# Altium schematic sheet W800.SchDoc
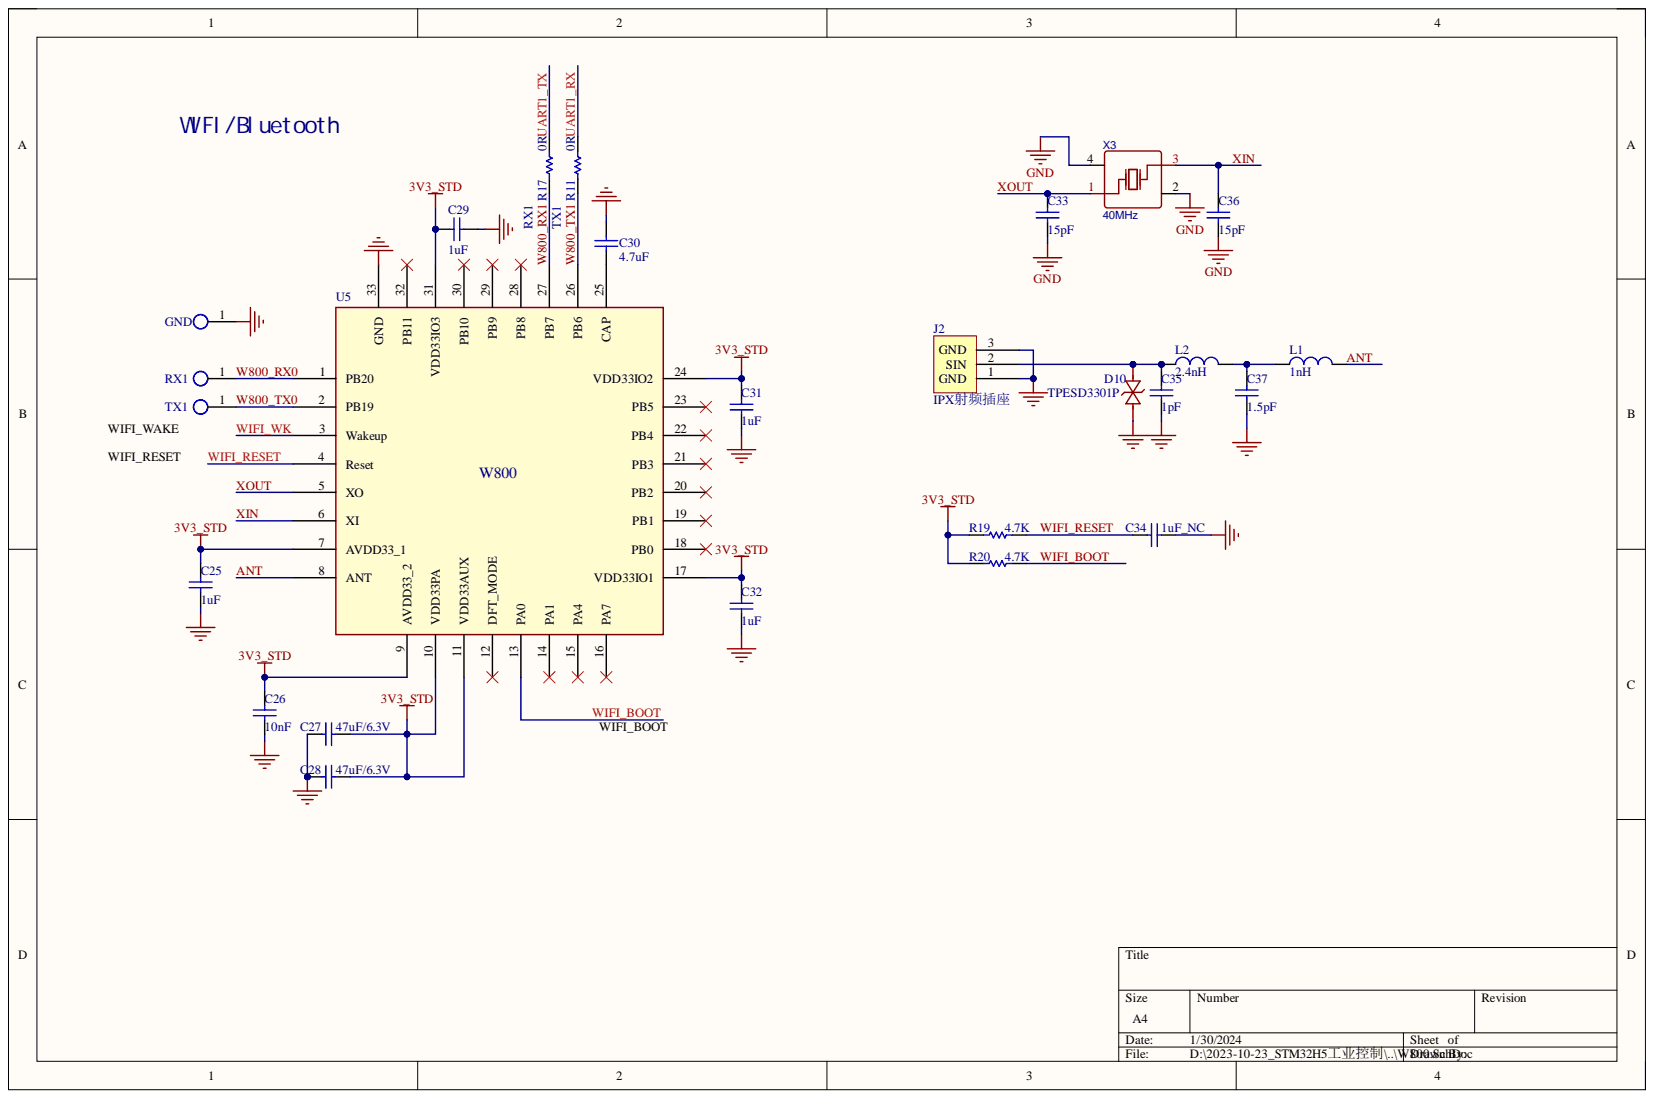
[diagram p0: W800.SchDoc]

## Parts
C25  1uF  [0201]
C26  10nF  [0201]
C27  47uF/6.3V  [0603]
C28  47uF/6.3V  [0603]
C29  1uF  [0201]
C30  4.7uF  [0402]
C31  1uF  [0201]
C32  1uF  [0201]
C33  15pF  [0201]
C34  1uF_NC  [0201]
C35  1pF  [0201]
C36  15pF  [0201]
C37  1.5pF  [0201]
D10  TPESD3301P  [DFN1006-2L-BI]
GND  =Value  [TP_0.5MM]
J2  U.FL-R-SMT-1(80)  [IPX_RECEPTACLE]  (value not drawn)
L1  1nH  [0201]
L2  2.4nH  [0201]
R11  0R  [0201]
R17  0R  [0201]
R19  4.7K  [0201]
R20  4.7K  [0201]
RX1  =Value  [TP_0.5MM]
TX1  =Value  [TP_0.5MM]
U5  W800  [QFN32_4X4_W800]
X3  40MHz  [CRYSTAL-SMD_4P-L2.5-W2.0-BL]

## Nets
3V3_STD: C25.1 C26.1 C27.1 C28.1 C29.1 C31.1 C32.1 R19.1 R20.1 U5.7(AVDD33_1) U5.9(AVDD33_2) U5.10(VDD33PA) U5.11(VDD33AUX) U5.17(VDD33IO1) U5.24(VDD33IO2) U5.31(VDD33IO3)
GND: C25.2 C26.2 C27.2 C28.2 C29.2 C30.1 C31.2 C32.2 C33.2 C34.2 C35.2 C36.2 C37.2 D10.1 GND.1 J2.1 J2.3 U5.33(GND) X3.2 X3.4
ANT: L1.2 U5.8(ANT)
UART1_RX: R11.2
UART1_TX: R17.2
W800_RX0: RX1.1 U5.1(PB20)
W800_RX1: R17.1 U5.27(PB7)
W800_TX0: TX1.1 U5.2(PB19)
W800_TX1: R11.1 U5.26(PB6)
WIFI_BOOT: R20.2 U5.13(PA0)
WIFI_RESET: C34.1 R19.2 U5.4(Reset)
WIFI_WK: U5.3(Wakeup)
XIN: C36.1 U5.6(XI) X3.3
XOUT: C33.1 U5.5(XO) X3.1
NetC30_2: C30.2 U5.25(CAP)
NetC35_1: C35.1 D10.2 J2.2 L2.1
NetC37_1: C37.1 L1.1 L2.2

Not connected: U5 12(DFT_MODE) 14(PA1) 15(PA4) 16(PA7) 18(PB0) 19(PB1) 20(PB2) 21(PB3) 22(PB4) 23(PB5) 28(PB8) 29(PB9) 30(PB10) 32(PB11)

## Library data of the parts
C25-C29 C31-C37 = Cap: Capacitor; PartNumber: CAP100NF; Component Kind: Standard; LatestRevisionDate: 17-Jul-2002; LatestRevisionNote: Re-released for DXP Platform.; Library Name: Miscellaneous Devices.IntLib; PackageReference: 0402; Published: 8-Jun-2000; Signal Integrity: Cap; Simulation: CAP; Publisher: Altium Limited
  C25 C29 C31 C32: Value: 1uF
  C26: Value: 10nF
  C27 C28: Value: 47uF/6.3V
  C33 C36: Value: 15pF
  C34: Value: 1uF_NC
  C35: Value: 1pF
  C37: Value: 1.5pF
C30 = Cap: Value: 100nF; Component Kind: Standard; Library Name: STLINK.SCHLIB
D10 = PT05D5CE_1: BOM_Manufacturer: PTTC(Polytronics Tech); Contributor: LCSC; BOM_Manufacturer Part: PT05D5CE; BOM_Supplier: LCSC; BOM_Supplier Part: C492000; Component Kind: Standard; Library Name: RA6M5.SCHLIB; spicePre: D; spiceSymbolName: PT05D5CE
GND RX1 TX1 = TP: Component Kind: Standard; Library Name: HM2.SchLib
J2 = IPX_RECEPTACLE: VALUE: IPX射频插座; Component Kind: Standard; JLC NO: C88374; Library Name: HM2.SchLib
L1 L2 = Inductor: Component Kind: Standard; Library Name: MyDevice.SchLib
  L1: Value: 1nH
  L2: Value: 2.4nH
R11 R17 R19 R20 = Res1: Resistor; PartNumber: RES2.2K; Component Kind: Standard; LatestRevisionDate: 17-Jul-2002; LatestRevisionNote: Re-released for DXP Platform.; Library Name: Miscellaneous Devices.IntLib; PackageReference: 0402; Published: 8-Jun-2000; Signal Integrity: Res; Simulation: RESISTOR; Publisher: Altium Limited
  R11 R17: Value: 0R
  R19 R20: Value: 4.7K
U5 = W600_10: Component Kind: Standard
X3 = 24MHz_1: BOM_Manufacturer: JGHC; BOM_Manufacturer Part: X252024MPB4SC; Contributor: LCSC; nameAlias: Frequency; BOM_Supplier: LCSC; BOM_Supplier Part: C65961; Component Kind: Standard; Library Name: HM2.SchLib; spicePre: X; spiceSymbolName: X252024MPB4SC
